# Altium schematic sheet LIDO-HW.300.StatusLightInterface.SchDoc(LIDO-HW.300.StatusLightInterface)
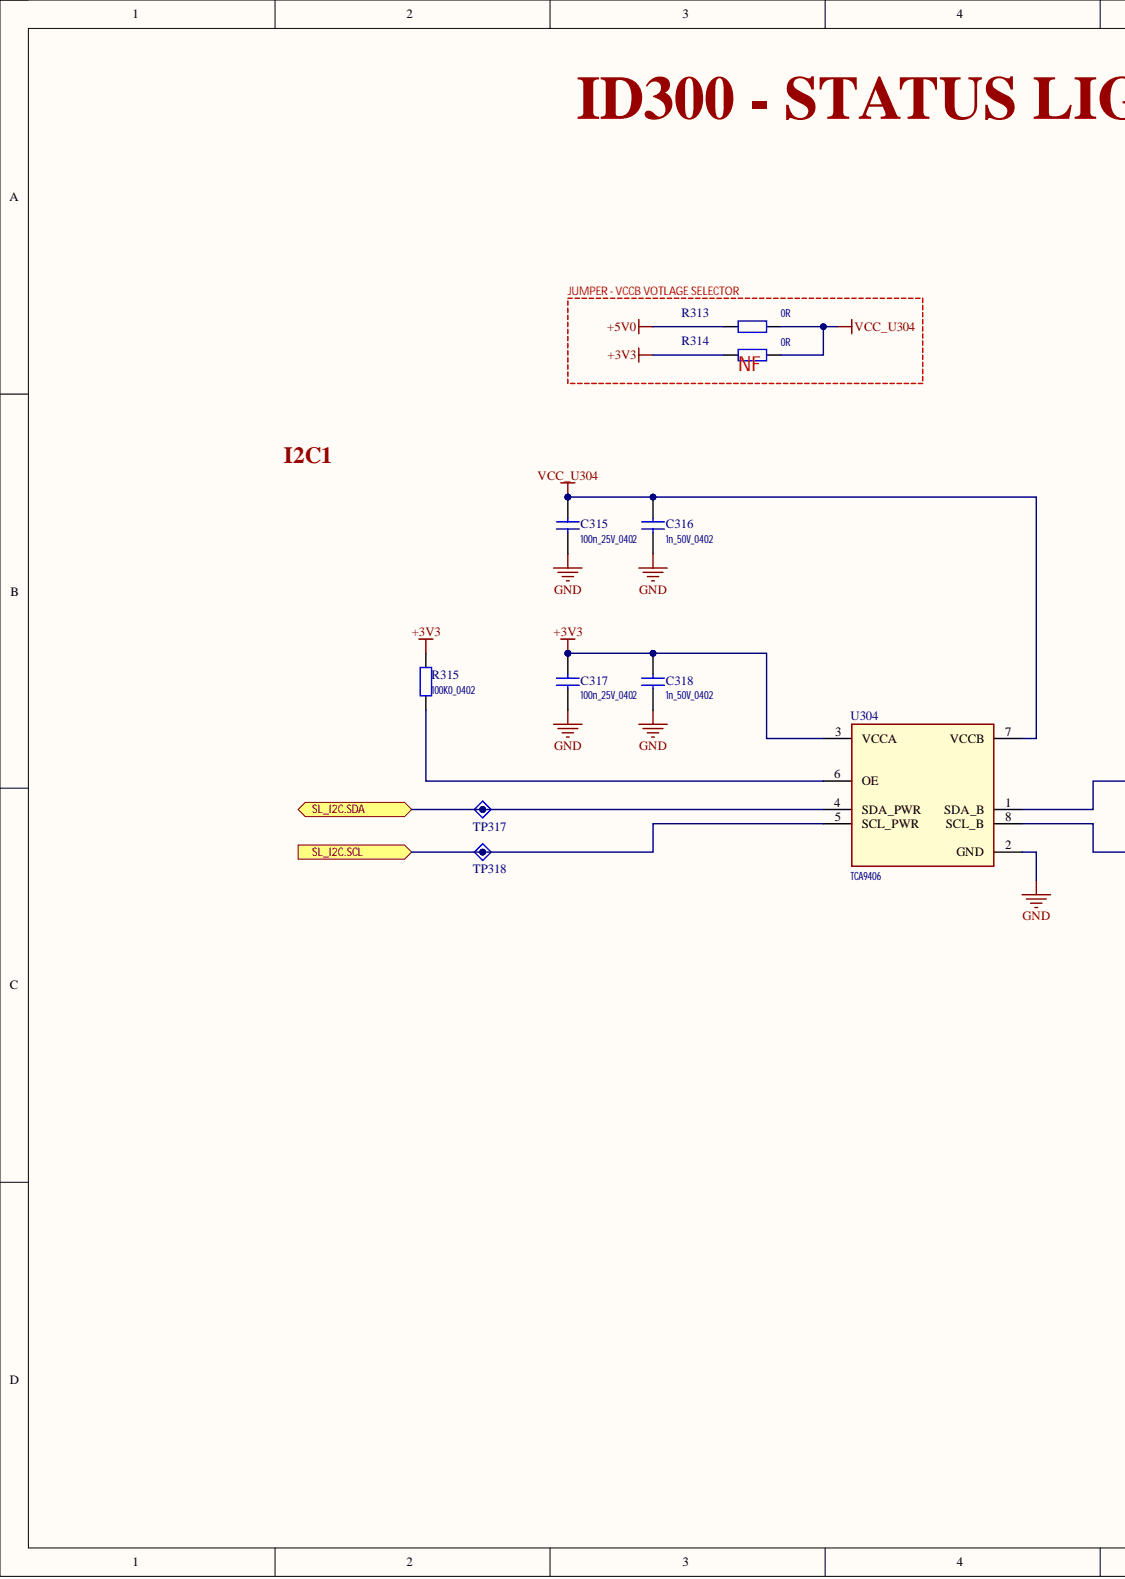
[diagram p0: LIDO-HW.300.StatusLightInterface.SchDoc, left]
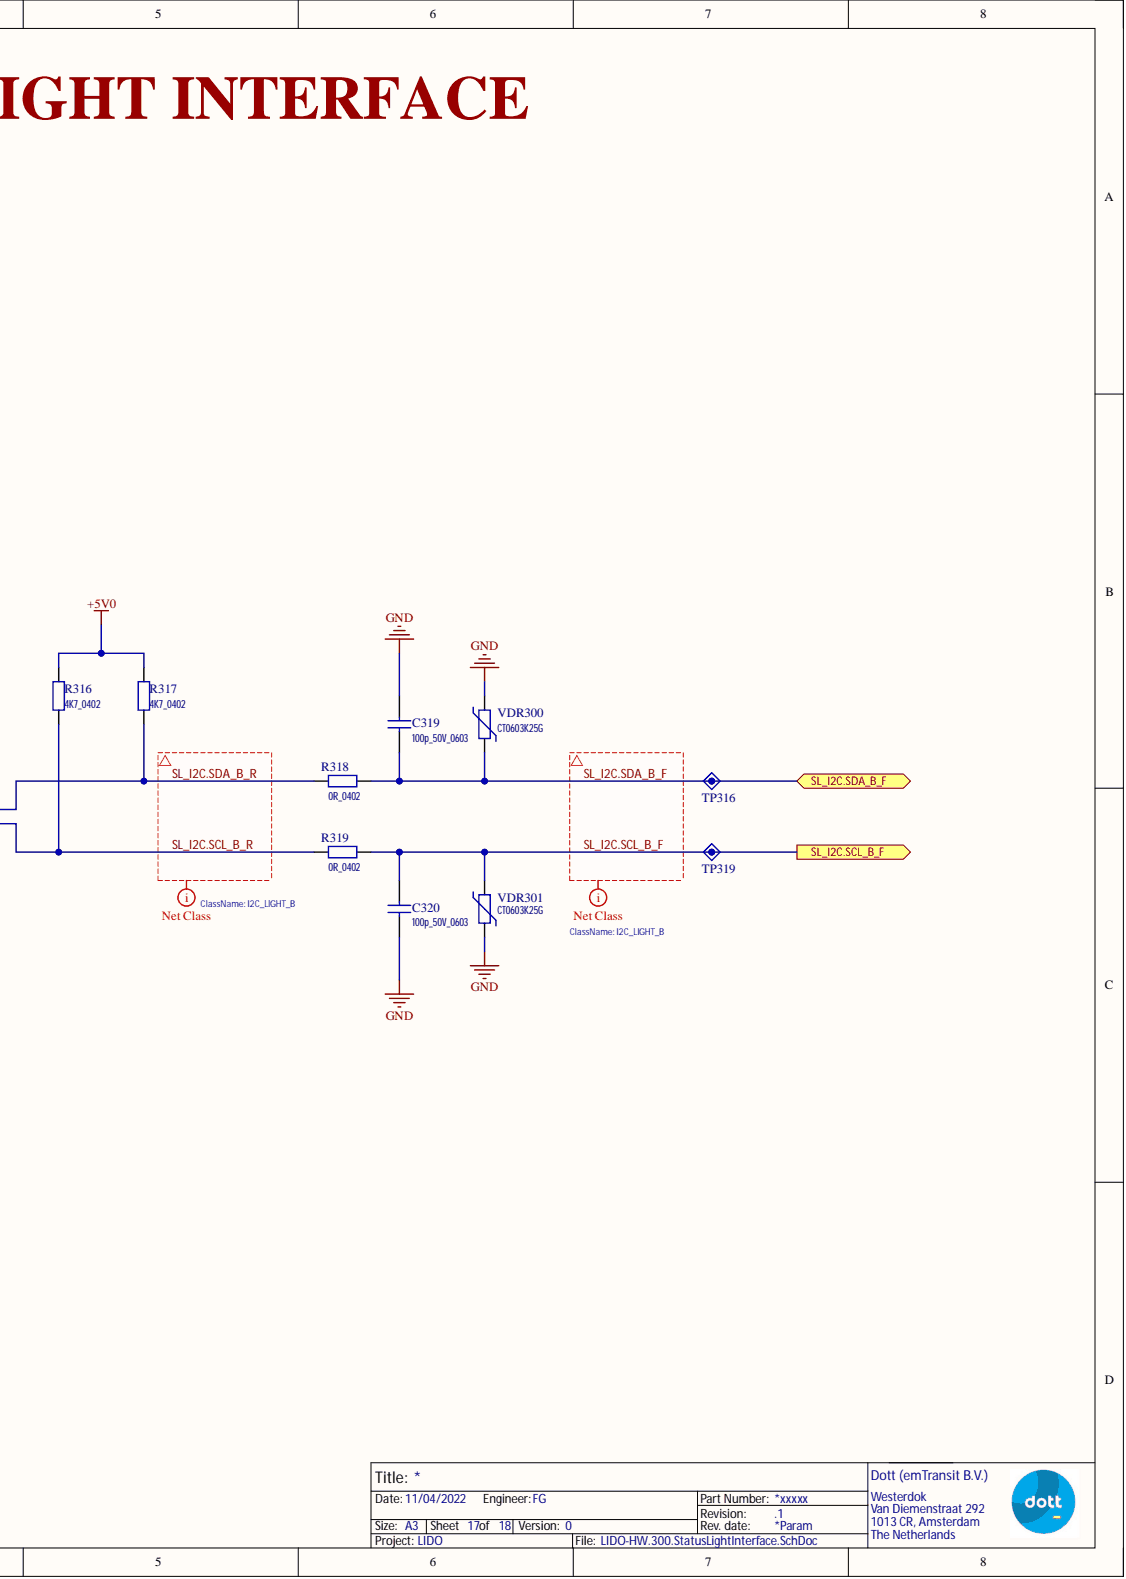
[diagram p1: LIDO-HW.300.StatusLightInterface.SchDoc, right]

## Parts
C315  100n_25V_0402
C316  1n_50V_0402
C317  100n_25V_0402
C318  1n_50V_0402
C319  100p_50V_0603
C320  100p_50V_0603
R313  0R
R314  0R
R315  100K0_0402
R316  4K7_0402
R317  4K7_0402
R318  0R_0402
R319  0R_0402
TP316  TestPoint_PWR  (value not drawn)  (no BOM)
TP317  TestPoint_PWR  (value not drawn)  (no BOM)
TP318  TestPoint_PWR  (value not drawn)  (no BOM)
TP319  TestPoint_PWR  (value not drawn)  (no BOM)
U304  TCA9406
VDR300  CT0603K25G
VDR301  CT0603K25G

## Ports
SL_I2C.SCL, SL_I2C.SCL_B_F, SL_I2C.SDA, SL_I2C.SDA_B_F

## Nets
+3V3: C317.1 C318.1 R314.1 R315.1 U304.3(VCCA)
+5V0: R313.1 R316.1 R317.1
GND: C315.2 C316.2 C317.2 C318.2 C319.1 C320.2 U304.2(GND) VDR300.1 VDR301.1
VCC_U304: C315.1 C316.1 R313.2 R314.2 U304.7(VCCB)
SL_I2C.SCL: TP318.1 U304.5(SCL_PWR) ; port SL_I2C.SCL
SL_I2C.SCL_B_F: C320.1 R319.2 TP319.1 VDR301.2 ; port SL_I2C.SCL_B_F
SL_I2C.SCL_B_R: R316.2 R319.1 U304.8(SCL_B)
SL_I2C.SDA: TP317.1 U304.4(SDA_PWR) ; port SL_I2C.SDA
SL_I2C.SDA_B_F: C319.2 R318.2 TP316.1 VDR300.2 ; port SL_I2C.SDA_B_F
SL_I2C.SDA_B_R: R317.2 R318.1 U304.1(SDA_B)
NetR315_2: R315.2 U304.6(OE)

## Library data of the parts
C315-C320 = Ceramic: Ceramic CAP Symbol; Component Kind: Standard; Library Name: 01_Capacitors.SchLib
R313-R319 = Resistor: Resistor Symbol; Component Kind: Standard; Library Name: 02_Resistors.SchLib
TP316-TP319 = TestPoint_A: Test Point Symbol verion A; 00_Name: TP_XXmm; 01_Diameter: 1mm; Library Name: 31_MFG_Elements.SchLib
U304 = TCA9406_A: 2-Bit Bidirectional 1-MHz, I  2C Bus and SMBus Voltage-Level Translator With 8-kV HBM ESD; Component Kind: Standard; Library Name: 15_IC_Logic.SchLib
VDR300 VDR301 = VARISTOR: Varistor Symbol; Component Kind: Standard; Library Name: 04_Circuit_Protection.SchLib
